# Altium schematic sheet 50SW_SCH_3.SchDoc
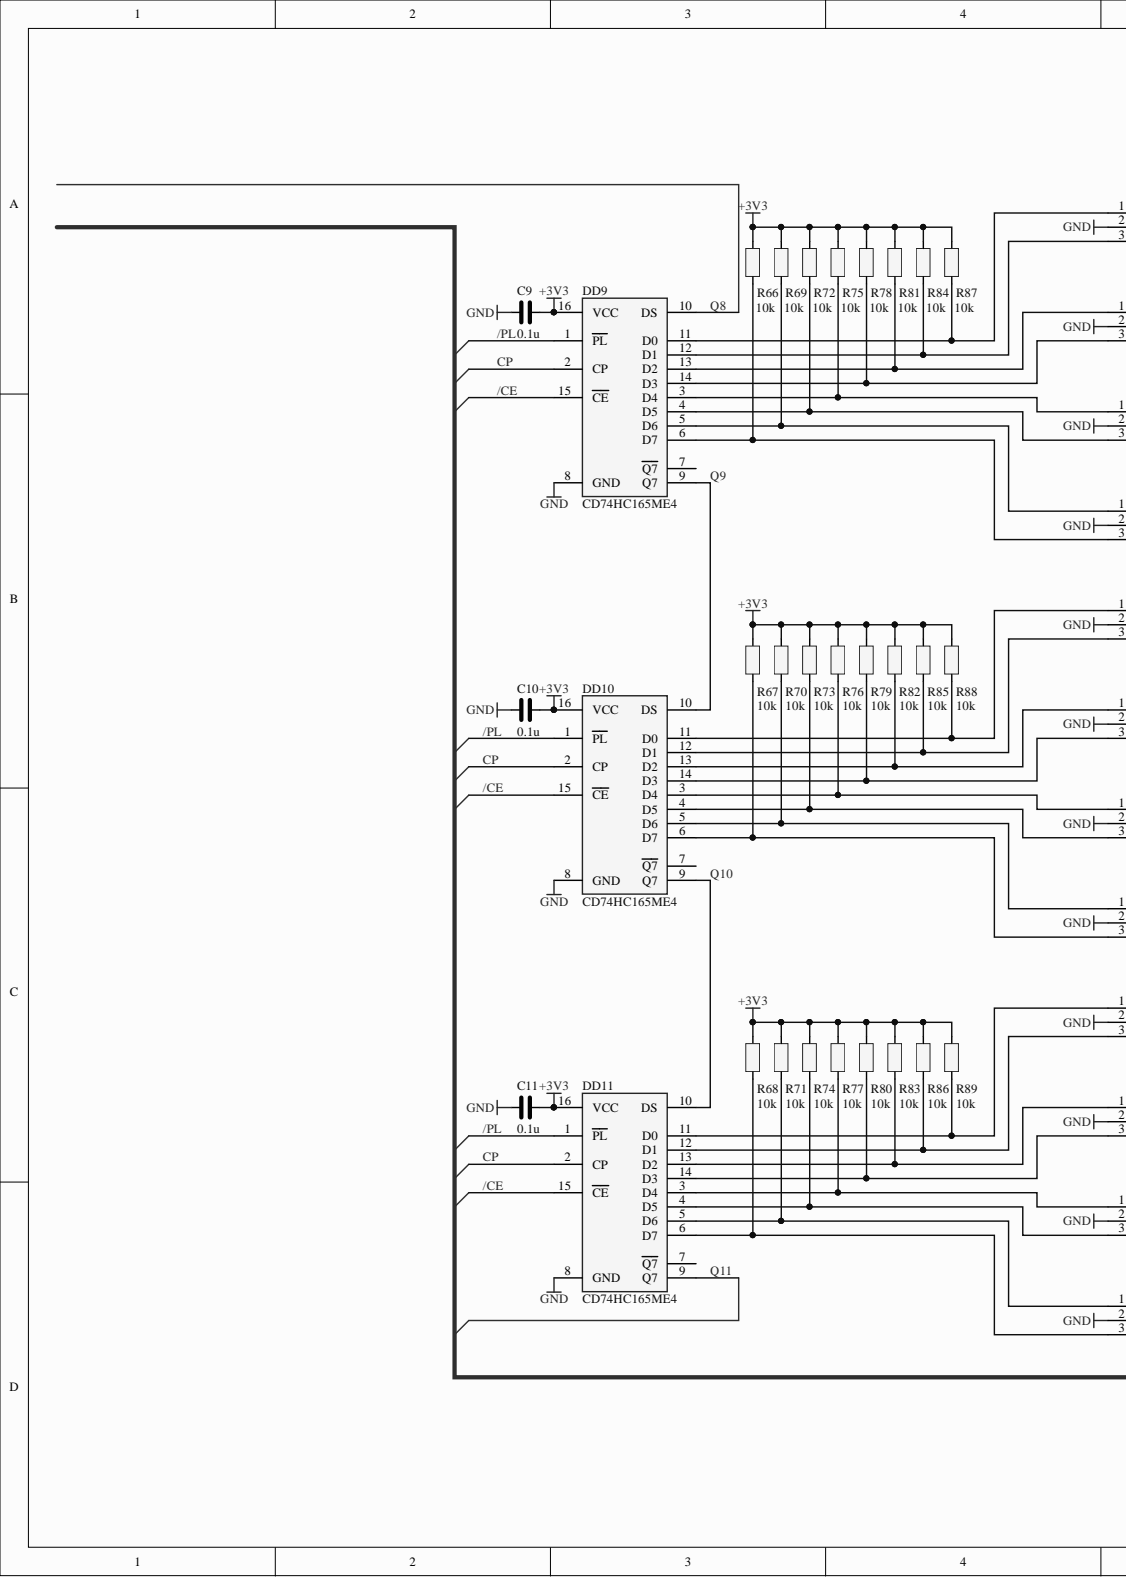
[diagram p0: 50SW_SCH_3.SchDoc, left]
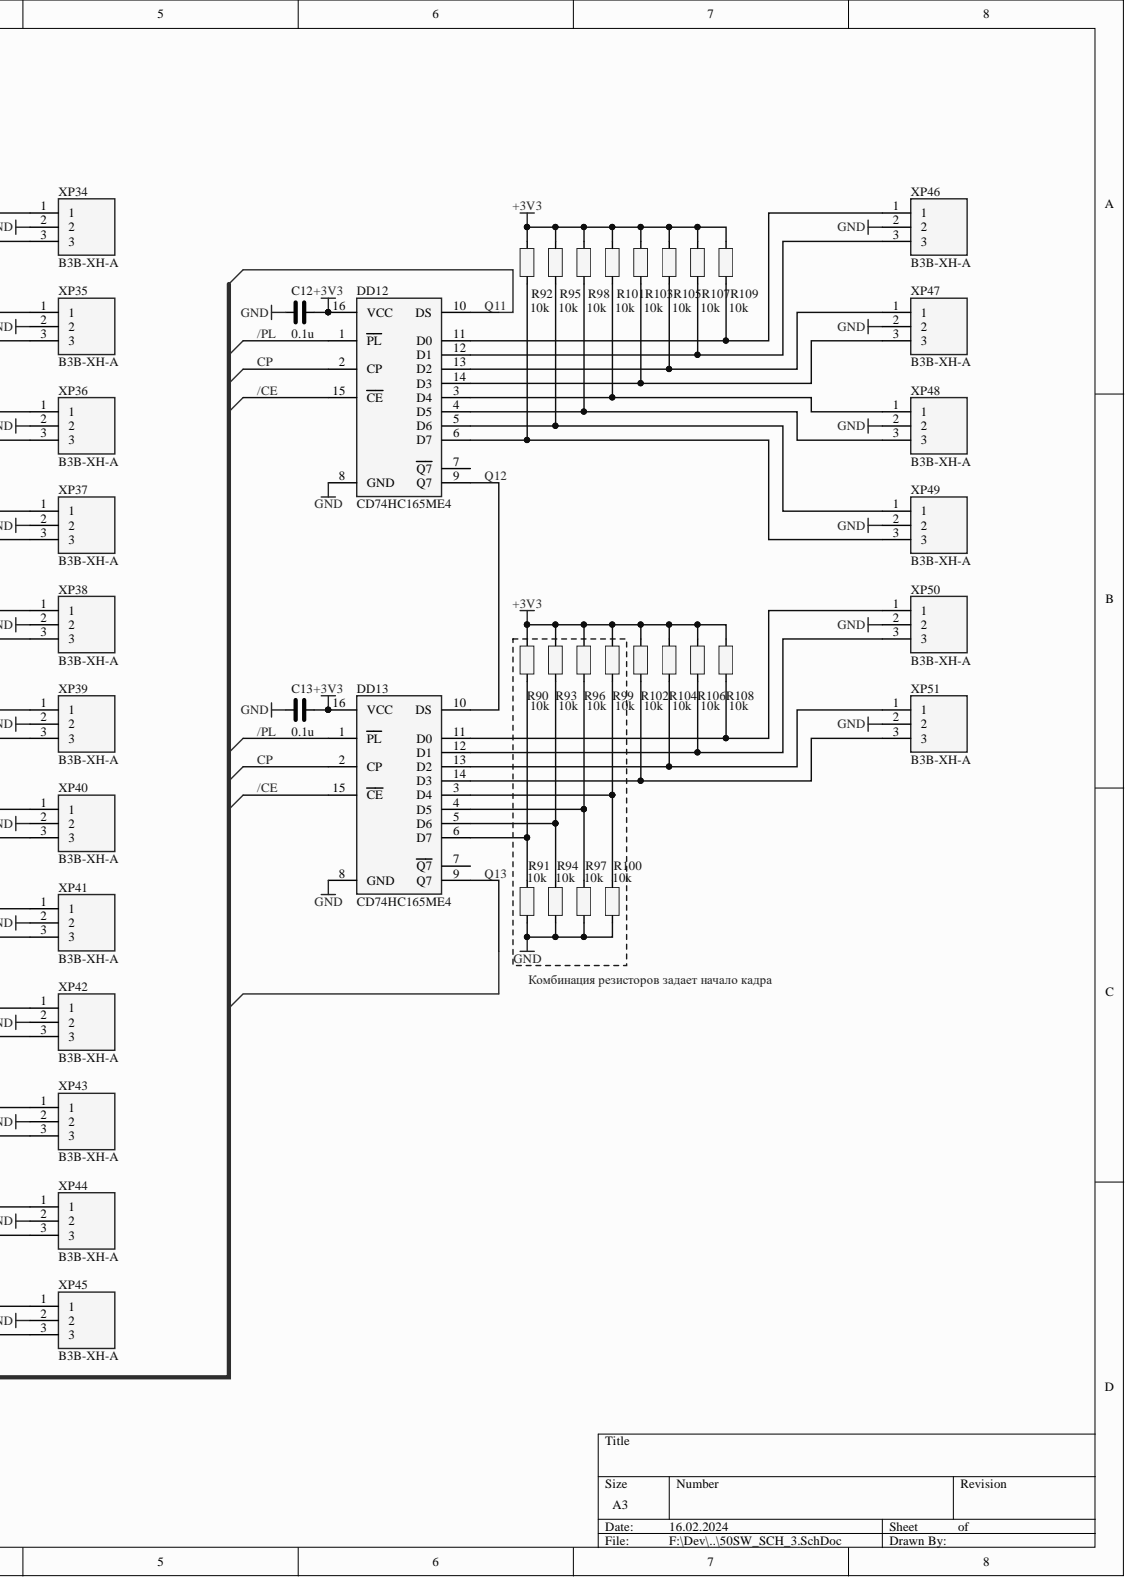
[diagram p1: 50SW_SCH_3.SchDoc, right]

## Parts
C9  0.1u  [C0603]
C10  0.1u  [C0603]
C11  0.1u  [C0603]
C12  0.1u  [C0603]
C13  0.1u  [C0603]
DD9  CD74HC165ME4  [SOIC127P600X175-16N]
DD10  CD74HC165ME4  [SOIC127P600X175-16N]
DD11  CD74HC165ME4  [SOIC127P600X175-16N]
DD12  CD74HC165ME4  [SOIC127P600X175-16N]
DD13  CD74HC165ME4  [SOIC127P600X175-16N]
R66  10k  [R0603]
R67  10k  [R0603]
R68  10k  [R0603]
R69  10k  [R0603]
R70  10k  [R0603]
R71  10k  [R0603]
R72  10k  [R0603]
R73  10k  [R0603]
R74  10k  [R0603]
R75  10k  [R0603]
R76  10k  [R0603]
R77  10k  [R0603]
R78  10k  [R0603]
R79  10k  [R0603]
R80  10k  [R0603]
R81  10k  [R0603]
R82  10k  [R0603]
R83  10k  [R0603]
R84  10k  [R0603]
R85  10k  [R0603]
R86  10k  [R0603]
R87  10k  [R0603]
R88  10k  [R0603]
R89  10k  [R0603]
R90  10k  [R0603]
R91  10k  [R0603]
R92  10k  [R0603]
R93  10k  [R0603]
R94  10k  [R0603]
R95  10k  [R0603]
R96  10k  [R0603]
R97  10k  [R0603]
R98  10k  [R0603]
R99  10k  [R0603]
R100  10k  [R0603]
R101  10k  [R0603]
R102  10k  [R0603]
R103  10k  [R0603]
R104  10k  [R0603]
R105  10k  [R0603]
R106  10k  [R0603]
R107  10k  [R0603]
R108  10k  [R0603]
R109  10k  [R0603]
XP34  B3B-XH-A  [SHDR3W64P0X250_1X3_990X575X700P]
XP35  B3B-XH-A  [SHDR3W64P0X250_1X3_990X575X700P]
XP36  B3B-XH-A  [SHDR3W64P0X250_1X3_990X575X700P]
XP37  B3B-XH-A  [SHDR3W64P0X250_1X3_990X575X700P]
XP38  B3B-XH-A  [SHDR3W64P0X250_1X3_990X575X700P]
XP39  B3B-XH-A  [SHDR3W64P0X250_1X3_990X575X700P]
XP40  B3B-XH-A  [SHDR3W64P0X250_1X3_990X575X700P]
XP41  B3B-XH-A  [SHDR3W64P0X250_1X3_990X575X700P]
XP42  B3B-XH-A  [SHDR3W64P0X250_1X3_990X575X700P]
XP43  B3B-XH-A  [SHDR3W64P0X250_1X3_990X575X700P]
XP44  B3B-XH-A  [SHDR3W64P0X250_1X3_990X575X700P]
XP45  B3B-XH-A  [SHDR3W64P0X250_1X3_990X575X700P]
XP46  B3B-XH-A  [SHDR3W64P0X250_1X3_990X575X700P]
XP47  B3B-XH-A  [SHDR3W64P0X250_1X3_990X575X700P]
XP48  B3B-XH-A  [SHDR3W64P0X250_1X3_990X575X700P]
XP49  B3B-XH-A  [SHDR3W64P0X250_1X3_990X575X700P]
XP50  B3B-XH-A  [SHDR3W64P0X250_1X3_990X575X700P]
XP51  B3B-XH-A  [SHDR3W64P0X250_1X3_990X575X700P]

## Nets
+3V3: C9.1 C10.1 C11.1 C12.1 C13.1 DD9.16(VCC) DD10.16(VCC) DD11.16(VCC) DD12.16(VCC) DD13.16(VCC) R66.1 R67.1 R68.1 R69.1 R70.1 R71.1 R72.1 R73.1 R74.1 R75.1 R76.1 R77.1 R78.1 R79.1 R80.1 R81.1 R82.1 R83.1 R84.1 R85.1 R86.1 R87.1 R88.1 R89.1 R90.1 R92.1 R93.1 R95.1 R96.1 R98.1 R99.1 R101.1 R102.1 R103.1 R104.1 R105.1 R106.1 R107.1 R108.1 R109.1
GND: C9.2 C10.2 C11.2 C12.2 C13.2 DD9.8(GND) DD10.8(GND) DD11.8(GND) DD12.8(GND) DD13.8(GND) R91.2 R94.2 R97.2 R100.2 XP34.2 XP35.2 XP36.2 XP37.2 XP38.2 XP39.2 XP40.2 XP41.2 XP42.2 XP43.2 XP44.2 XP45.2 XP46.2 XP47.2 XP48.2 XP49.2 XP50.2 XP51.2
/CE: DD9.15(CE) DD10.15(CE) DD11.15(CE) DD12.15(CE) DD13.15(CE)
/PL: DD9.1(PL) DD10.1(PL) DD11.1(PL) DD12.1(PL) DD13.1(PL)
CP: DD9.2(CP) DD10.2(CP) DD11.2(CP) DD12.2(CP) DD13.2(CP)
Q8: DD9.10(DS)
Q9: DD9.9(Q7) DD10.10(DS)
Q10: DD10.9(Q7) DD11.10(DS)
Q11: DD11.9(Q7) DD12.10(DS)
Q12: DD12.9(Q7) DD13.10(DS)
Q13: DD13.9(Q7)
NetDD9_3: DD9.3(D4) R75.2 XP36.1
NetDD9_4: DD9.4(D5) R72.2 XP36.3
NetDD9_5: DD9.5(D6) R69.2 XP37.1
NetDD9_6: DD9.6(D7) R66.2 XP37.3
NetDD9_11: DD9.11(D0) R87.2 XP34.1
NetDD9_12: DD9.12(D1) R84.2 XP34.3
NetDD9_13: DD9.13(D2) R81.2 XP35.1
NetDD9_14: DD9.14(D3) R78.2 XP35.3
NetDD10_3: DD10.3(D4) R76.2 XP40.1
NetDD10_4: DD10.4(D5) R73.2 XP40.3
NetDD10_5: DD10.5(D6) R70.2 XP41.1
NetDD10_6: DD10.6(D7) R67.2 XP41.3
NetDD10_11: DD10.11(D0) R88.2 XP38.1
NetDD10_12: DD10.12(D1) R85.2 XP38.3
NetDD10_13: DD10.13(D2) R82.2 XP39.1
NetDD10_14: DD10.14(D3) R79.2 XP39.3
NetDD11_3: DD11.3(D4) R77.2 XP44.1
NetDD11_4: DD11.4(D5) R74.2 XP44.3
NetDD11_5: DD11.5(D6) R71.2 XP45.1
NetDD11_6: DD11.6(D7) R68.2 XP45.3
NetDD11_11: DD11.11(D0) R89.2 XP42.1
NetDD11_12: DD11.12(D1) R86.2 XP42.3
NetDD11_13: DD11.13(D2) R83.2 XP43.1
NetDD11_14: DD11.14(D3) R80.2 XP43.3
NetDD12_3: DD12.3(D4) R101.2 XP48.1
NetDD12_4: DD12.4(D5) R98.2 XP48.3
NetDD12_5: DD12.5(D6) R95.2 XP49.1
NetDD12_6: DD12.6(D7) R92.2 XP49.3
NetDD12_11: DD12.11(D0) R109.2 XP46.1
NetDD12_12: DD12.12(D1) R107.2 XP46.3
NetDD12_13: DD12.13(D2) R105.2 XP47.1
NetDD12_14: DD12.14(D3) R103.2 XP47.3
NetDD13_3: DD13.3(D4) R99.2 R100.1
NetDD13_4: DD13.4(D5) R96.2 R97.1
NetDD13_5: DD13.5(D6) R93.2 R94.1
NetDD13_6: DD13.6(D7) R90.2 R91.1
NetDD13_11: DD13.11(D0) R108.2 XP50.1
NetDD13_12: DD13.12(D1) R106.2 XP50.3
NetDD13_13: DD13.13(D2) R104.2 XP51.1
NetDD13_14: DD13.14(D3) R102.2 XP51.3

Not connected: DD9 7(Q7); DD10 7(Q7); DD11 7(Q7); DD12 7(Q7); DD13 7(Q7)

## Library data of the parts
C9-C13 = C0603: BOMVal: 0603-X7R-16 В-0.1 мкФ ±10% керамический; Dielectric: X7R; Scale: u; ScaleR: мк; SizeImp: 0603; Tolerance: 10; TypeR: керамический; Value: 0.1; Voltage: 16; Component Kind: Standard; Library Name: passive.SchLib
DD9-DD13 = CD74HC165ME4: Integrated Circuit; Manufacturer_Name: Texas Instruments; BOMVal: Микросхема  CD74HC165ME4; NameR: Микросхема; Component Kind: Standard; Datasheet Link: https://www.ti.com/lit/gpn/cd74hc165; Height: 1.75mm; Library Name: SamacSys.SchLib; PartNumber: CD74HC165ME4
R66-R109 = R0603: BOMVal: 0603-0.1 Вт-10 кОмОм±5%; Power: 0.1; Scale: k; ScaleR: кОм; SizeImp: 0603; Tolerance: 5; Value: 10; Component Kind: Standard; Library Name: passive.SchLib
XP34-XP51 = B3B-XH-A: Connector; Manufacturer_Name: JST (JAPAN SOLDERLESS TERMINALS); BOMVal: Вилка на плату B3B-XH-A JST (JAPAN SOLDERLESS TERMINALS); NameR: Вилка; TypeR: на плату; Component Kind: Standard; Datasheet Link: http://www.jst-mfg.com/product/pdf/eng/eXH.pdf; Height: 7mm; Library Name: SamacSys_By.SchLib; PartNumber: B3B-XH-A
